# Altium schematic sheet discrete_parts.SchDoc
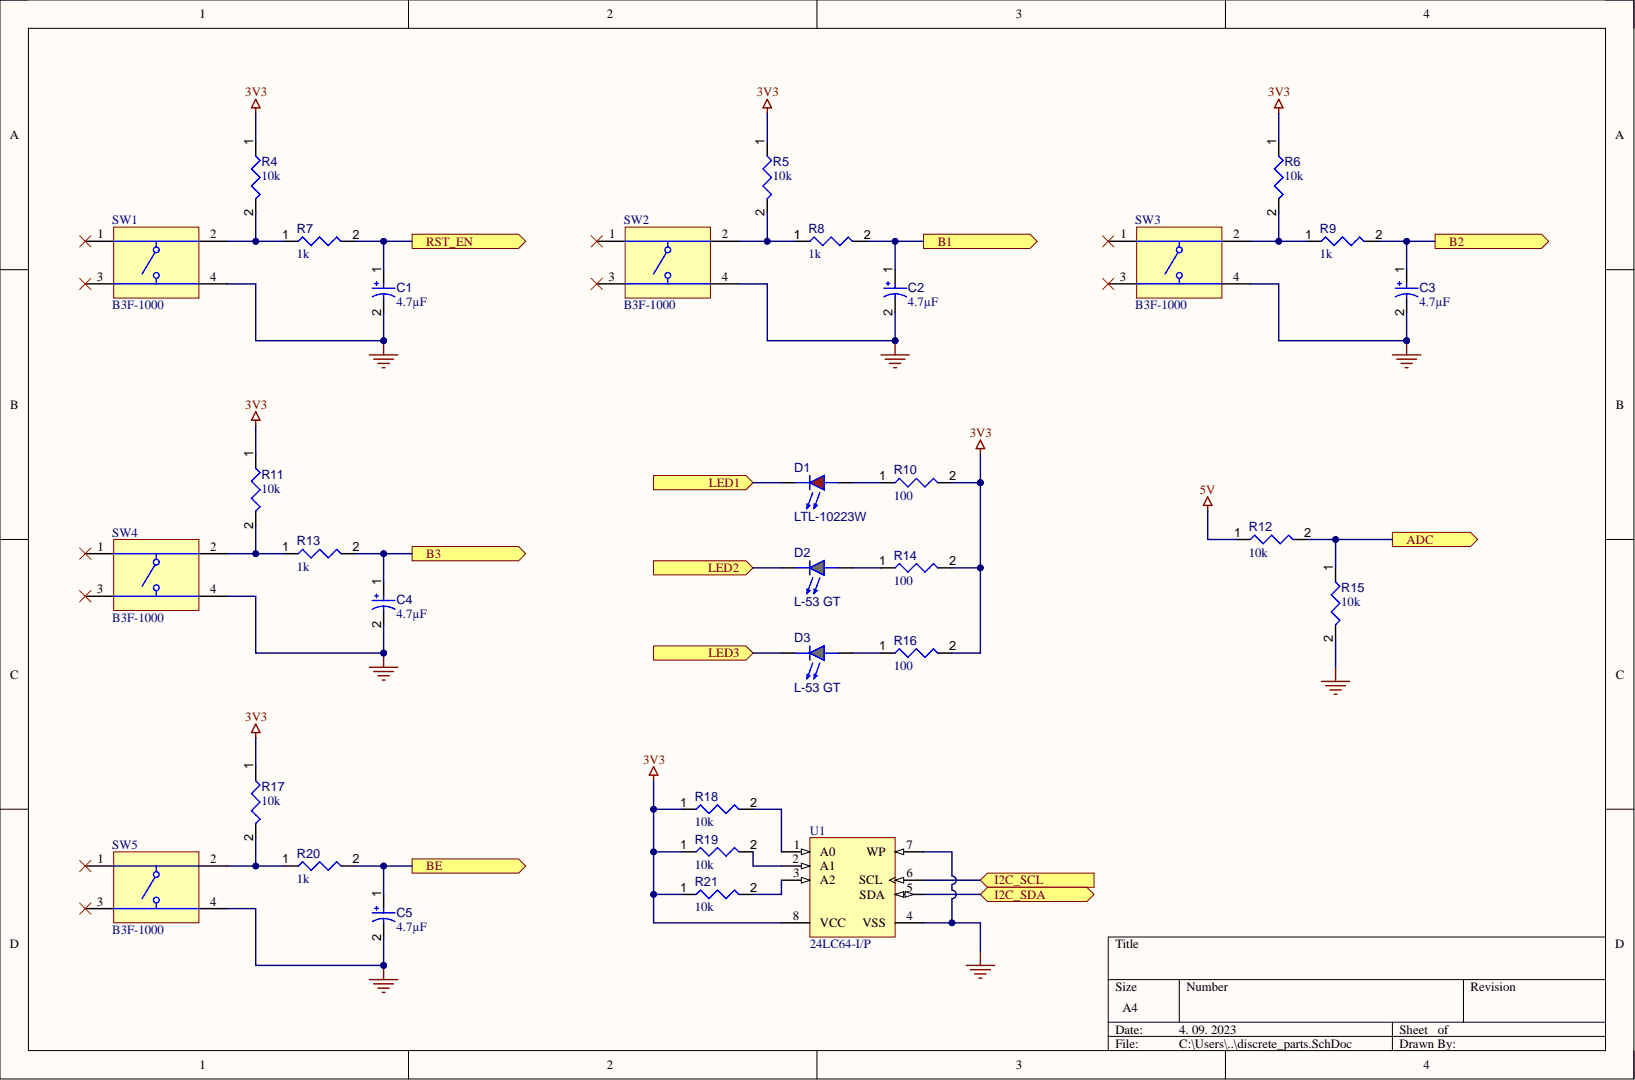
[diagram p0: discrete_parts.SchDoc]

## Parts
C1  value 4.7µF  [CAPPR55-200-1100X500X1200]  (hidden comment: ECA1HM4R7)
C2  value 4.7µF  [CAPPR55-200-1100X500X1200]  (hidden comment: ECA1HM4R7)
C3  value 4.7µF  [CAPPR55-200-1100X500X1200]  (hidden comment: ECA1HM4R7)
C4  value 4.7µF  [CAPPR55-200-1100X500X1200]  (hidden comment: ECA1HM4R7)
C5  value 4.7µF  [CAPPR55-200-1100X500X1200]  (hidden comment: ECA1HM4R7)
D1  LTL-10223W  [LED_RED]
D2  L-53 GT  [LED_GREEN]
D3  L-53 GT  [LED_GREEN]
R4  value 10k  [RESA60-630X240]  (hidden comment: MFR-25FBF52-10K)
R5  value 10k  [RESA60-630X240]  (hidden comment: MFR-25FBF52-10K)
R6  value 10k  [RESA60-630X240]  (hidden comment: MFR-25FBF52-10K)
R7  value 1k  [RESA60-630X240]  (hidden comment: MFR-25FBF52-10K)
R8  value 1k  [RESA60-630X240]  (hidden comment: MFR-25FBF52-10K)
R9  value 1k  [RESA60-630X240]  (hidden comment: MFR-25FBF52-10K)
R10  value 100  [RESA60-630X240]  (hidden comment: MFR-25FBF52-10K)
R11  value 10k  [RESA60-630X240]  (hidden comment: MFR-25FBF52-10K)
R12  value 10k  [RESA60-630X240]  (hidden comment: MFR-25FBF52-10K)
R13  value 1k  [RESA60-630X240]  (hidden comment: MFR-25FBF52-10K)
R14  value 100  [RESA60-630X240]  (hidden comment: MFR-25FBF52-10K)
R15  value 10k  [RESA60-630X240]  (hidden comment: MFR-25FBF52-10K)
R16  value 100  [RESA60-630X240]  (hidden comment: MFR-25FBF52-10K)
R17  value 10k  [RESA60-630X240]  (hidden comment: MFR-25FBF52-10K)
R18  value 10k  [RESA60-630X240]  (hidden comment: MFR-25FBF52-10K)
R19  value 10k  [RESA60-630X240]  (hidden comment: MFR-25FBF52-10K)
R20  value 1k  [RESA60-630X240]  (hidden comment: MFR-25FBF52-10K)
R21  value 10k  [RESA60-630X240]  (hidden comment: MFR-25FBF52-10K)
SW1  B3F-1000  [OMRON-B3F-1000-4_V]
SW2  B3F-1000  [OMRON-B3F-1000-4_V]
SW3  B3F-1000  [OMRON-B3F-1000-4_V]
SW4  B3F-1000  [OMRON-B3F-1000-4_V]
SW5  B3F-1000  [OMRON-B3F-1000-4_V]
U1  24LC64-I/P  [PDIP300-P8]

## Ports
ADC, B1, B2, B3, BE, I2C_SCL, I2C_SDA, LED1, LED2, LED3, RST_EN

## Nets
3V3: R4.1 R5.1 R6.1 R10.2 R11.1 R14.2 R16.2 R17.1 R18.1 R19.1 R21.1 U1.8(VCC)
5V: R12.1
GND: C1.2 C2.2 C3.2 C4.2 C5.2 R15.2 SW1.4 SW2.4 SW3.4 SW4.4 SW5.4 U1.4(VSS) U1.7(WP)
ADC: R12.2 R15.1 ; port ADC
B1: C2.1 R8.2 ; port B1
B2: C3.1 R9.2 ; port B2
B3: C4.1 R13.2 ; port B3
BE: C5.1 R20.2 ; port BE
I2C_SCL: U1.6(SCL) ; port I2C_SCL
I2C_SDA: U1.5(SDA) ; port I2C_SDA
LED1: D1.1 ; port LED1
LED2: D2.1 ; port LED2
LED3: D3.1 ; port LED3
RST_EN: C1.1 R7.2 ; port RST_EN
NetD1_2: D1.2 R10.1
NetD2_2: D2.2 R14.1
NetD3_2: D3.2 R16.1
NetR4_2: R4.2 R7.1 SW1.2
NetR5_2: R5.2 R8.1 SW2.2
NetR6_2: R6.2 R9.1 SW3.2
NetR11_2: R11.2 R13.1 SW4.2
NetR17_2: R17.2 R20.1 SW5.2
NetR18_2: R18.2 U1.1(A0)
NetR19_2: R19.2 U1.2(A1)
NetR21_2: R21.2 U1.3(A2)

Not connected: SW1 1 3; SW2 1 3; SW3 1 3; SW4 1 3; SW5 1 3

## Library data of the parts
C1-C5 = CMP-001-00033-7: Aluminum Electrolytic Capacitor, 4.7 uF, +/- 20%, 50 V, -40 to 85 degC, 2-Pin THD, RoHS, Bulk; Manufacturer: Panasonic; Ripple Current: 45mA; Voltage Rating: 50V; Capacitor Type: Electrolytic; Case/Package: Radial; Component Kind: Standard; Datasheet URL: https://industrial.panasonic.com/cdbs/www-data/pdf/RDF0000/ABA0000C1218.pdf; Datasheet Version: Rev. 05, 10/2016; Diameter: 5mm; Lead Pitch: 2mm; Manufacturer URL: http://www.panasonic.com/; Max Operating Temperature: 85°C; Min Operating Temperature: -40°C; Mounting Technology: TH; Package Description: 2-Pin Radial Lead, Dia 5 mm, Height 12 mm; Package Quantity: 200; Package Reference: CAPPR55-200-1100X500X1200; Packaging: Bulk; Pins: 2; Ripple Current (AC): 45mA; RoHS Compliant: True; Tolerance: 20%; Library Name: Budapest University of Technology and Economics
D1 = CMP-007-00037-1: Single Color LED, High Efficiency Red, Red Diffused, T-1 3/4, 4.75mm; Forward Current: 30mA; Forward Voltage: 2.8V; Colour: Red; Component Kind: Standard; Max Operating Temperature: 100°C; Min Operating Temperature: -55°C; Mounting Technology: Radial; Pins: 2; RoHS Compliant: Yes; Library Name: Budapest University of Technology and Economics
D2 D3 = CMP-007-00036-1: Green T-1 3/4 5 mm 60° Tinted Diffused 600 mcd 1.85 V LED Lamp Through Hole; Forward Current: 10mA; Forward Voltage: 2.2V; Colour: Green; Component Kind: Standard; Mounting Technology: Through Hole; Pins: 2; Library Name: Budapest University of Technology and Economics
R4-R21 = CMP-009-00047-4: Axial Resistor, 10 KOhm, +/- 1%, 0.25 W, -55 to 155 degC, 2-Pin THD, RoHS, Bulk; Manufacturer: Yageo; Power: 250mW; Voltage Rating: 250V; Case/Package: Axial; Component Kind: Standard; Datasheet URL: http://www.yageo.com/documents/recent/Yageo%20LR_MFR_2013.pdf; Datasheet Version: Apr-13; Manufacturer URL: http://www.yageo.com/; Max Operating Temperature: 155°C; Min Operating Temperature: -55°C; Mounting Technology: TH; Package Description: 2-Pin Axial Lead, Dia 2.4 mm, Body Length 6.3 mm; Package Quantity: 200; Package Reference: MFR-25; Packaging: Bulk; RoHS Compliant: True; Tolerance: 1%; Library Name: Budapest University of Technology and Economics
SW1-SW5 = CMP-011-00004-2: Tactile Switch, SPST-NO, 0.05 A, 24 V, -25 to 70 degC, 4-Pin THD, RoHS, Bulk; Manufacturer: Omron Electronic Components; Contact Current Rating: 50mA; Max Contact Resistance: 100mohm; Max Voltage Rating (DC): 24V; Operating Force: 100gf; Resistance: 0.1Ohm; Throw Configuration: SPST; Case/Package: B3F-1000; Component Kind: Standard; Contact Material: Gold; Contact Plating: Gold; Current Rating: 50mA; Datasheet URL: http://www.omron.com/ecb/products/pdf/en-b3f.pdf; Datasheet Version: Jan-15; Manufacturer URL: http://www.omron.com/; Max Current Rating: 50mA; Max Operating Temperature: 70°C; Min Operating Temperature: -25°C; Mounting Technology: TH; Package Description: 4-Pin Through Hole Device, Body 6 x 6 mm; Package Quantity: 1500; Package Reference: B3F-1000; Packaging: Bulk; Pins: 4; RoHS Compliant: True; Voltage Rating: 24V; Voltage Rating (DC): 24V; Library Name: Budapest University of Technology and Economics
U1 = CMP-019-00051-1: EEPROM Serial-I2C 64K-bit 8K x 8 3.3V/5V 8-Pin PDIP Tube; Clock Frequency: 400kHz; Max Supply Voltage: 5.5V; Memory Size: 64Kb; Min Supply Voltage: 2.5V; Case/Package: PDIP; Component Kind: Standard; Frequency: 400kHz; Max Operating Temperature: 85°C; Memory Format: EEPROM; Min Operating Temperature: -40°C; Mounting Technology: Through Hole; Pins: 8; RoHS Compliant: Yes; Library Name: Budapest University of Technology and Economics
